# Altium schematic sheet Sheet1.SchDoc
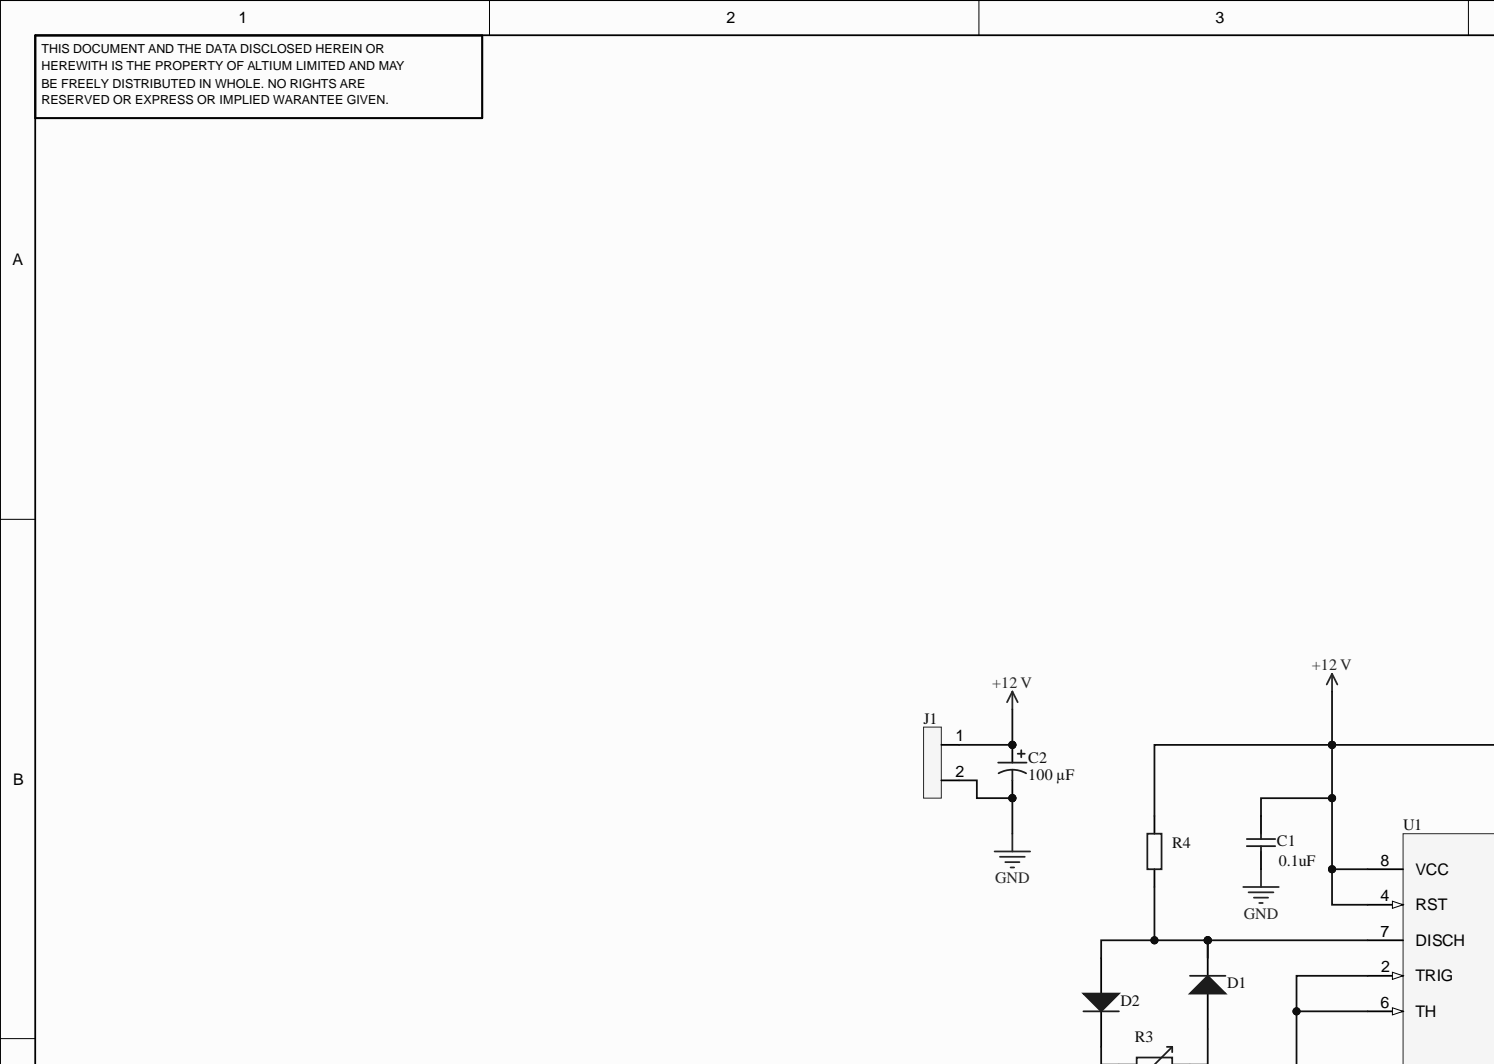
[diagram p0: Sheet1.SchDoc, top left]
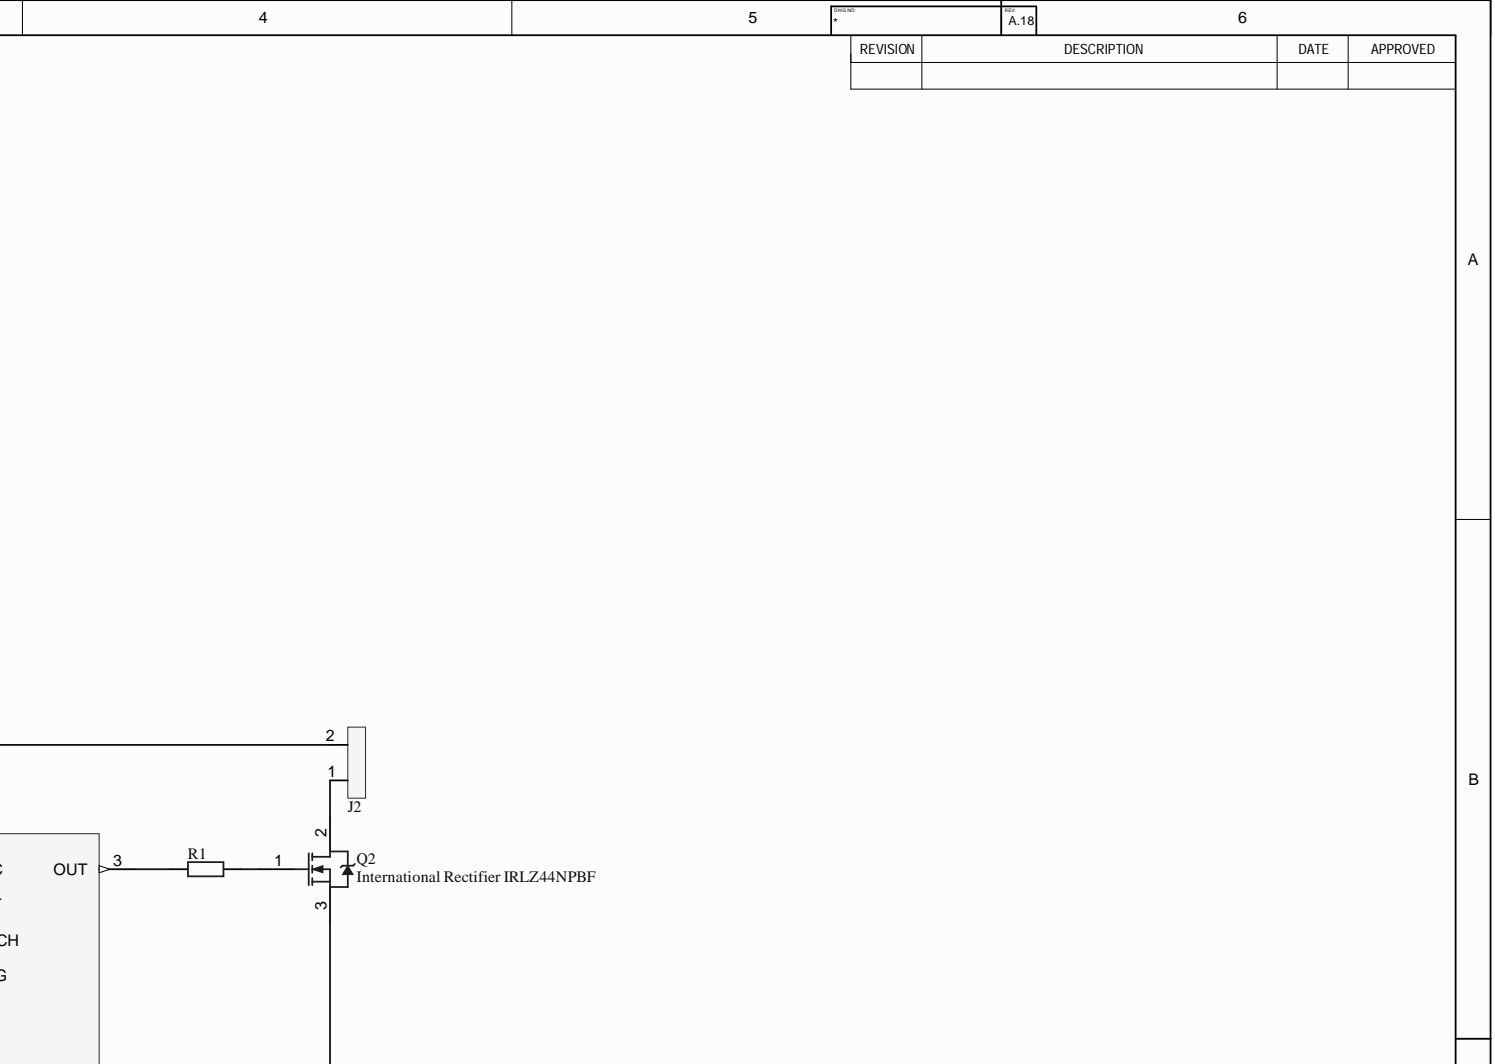
[diagram p1: Sheet1.SchDoc, top right]
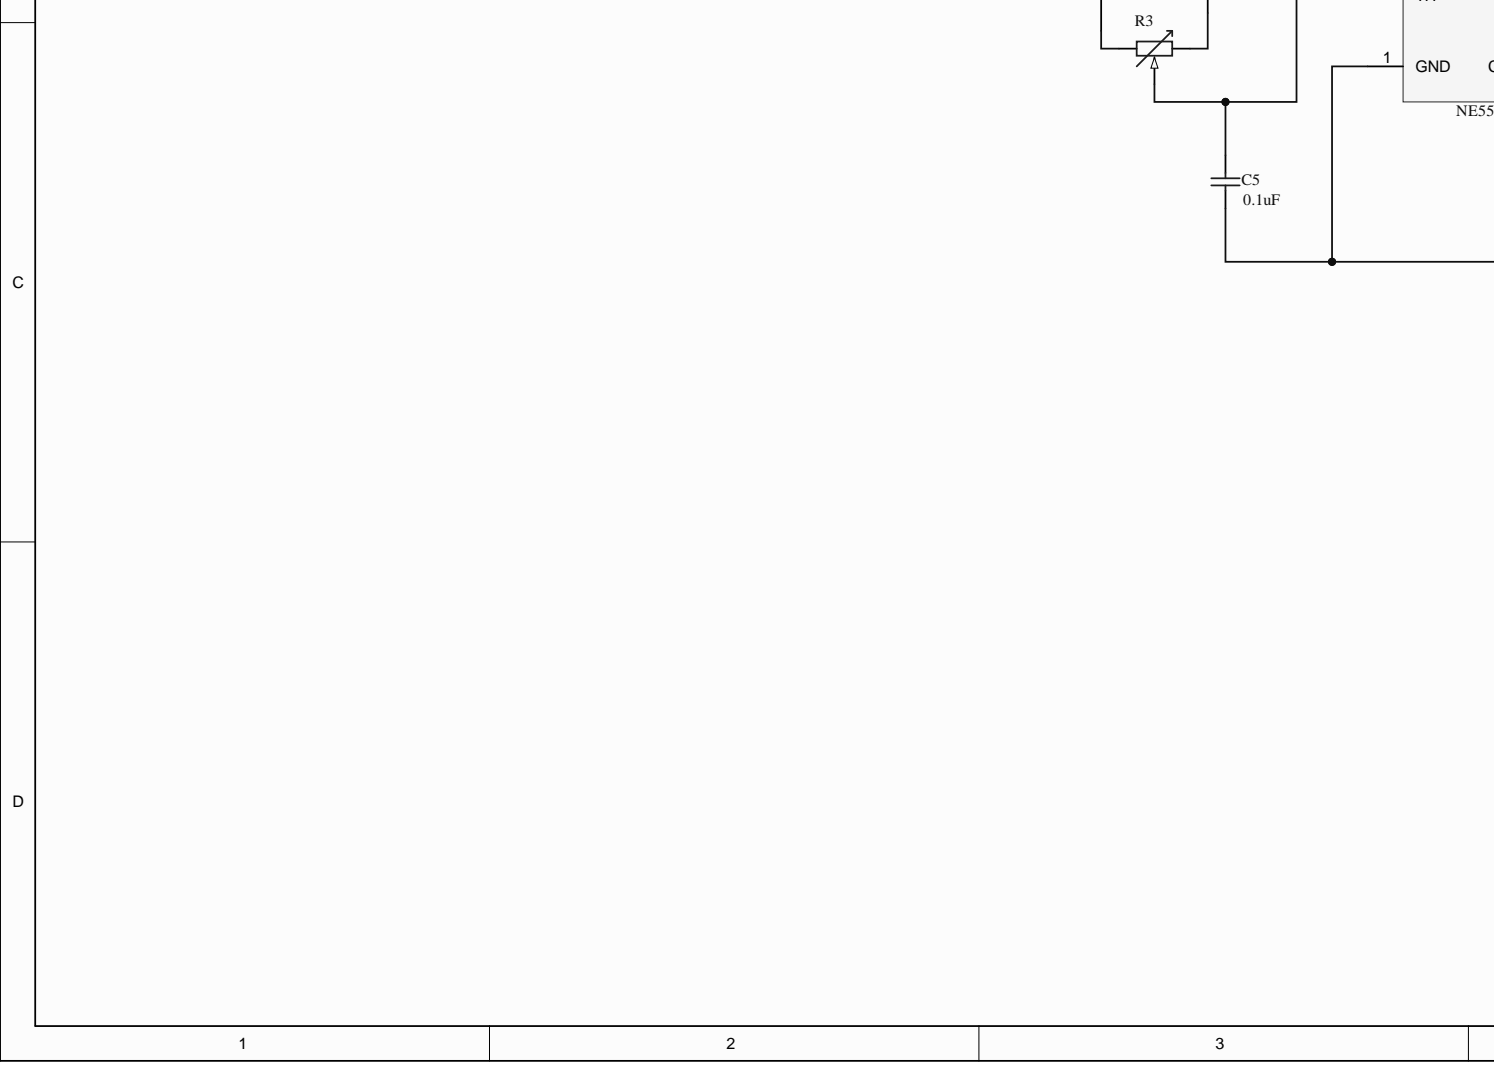
[diagram p2: Sheet1.SchDoc, bottom left]
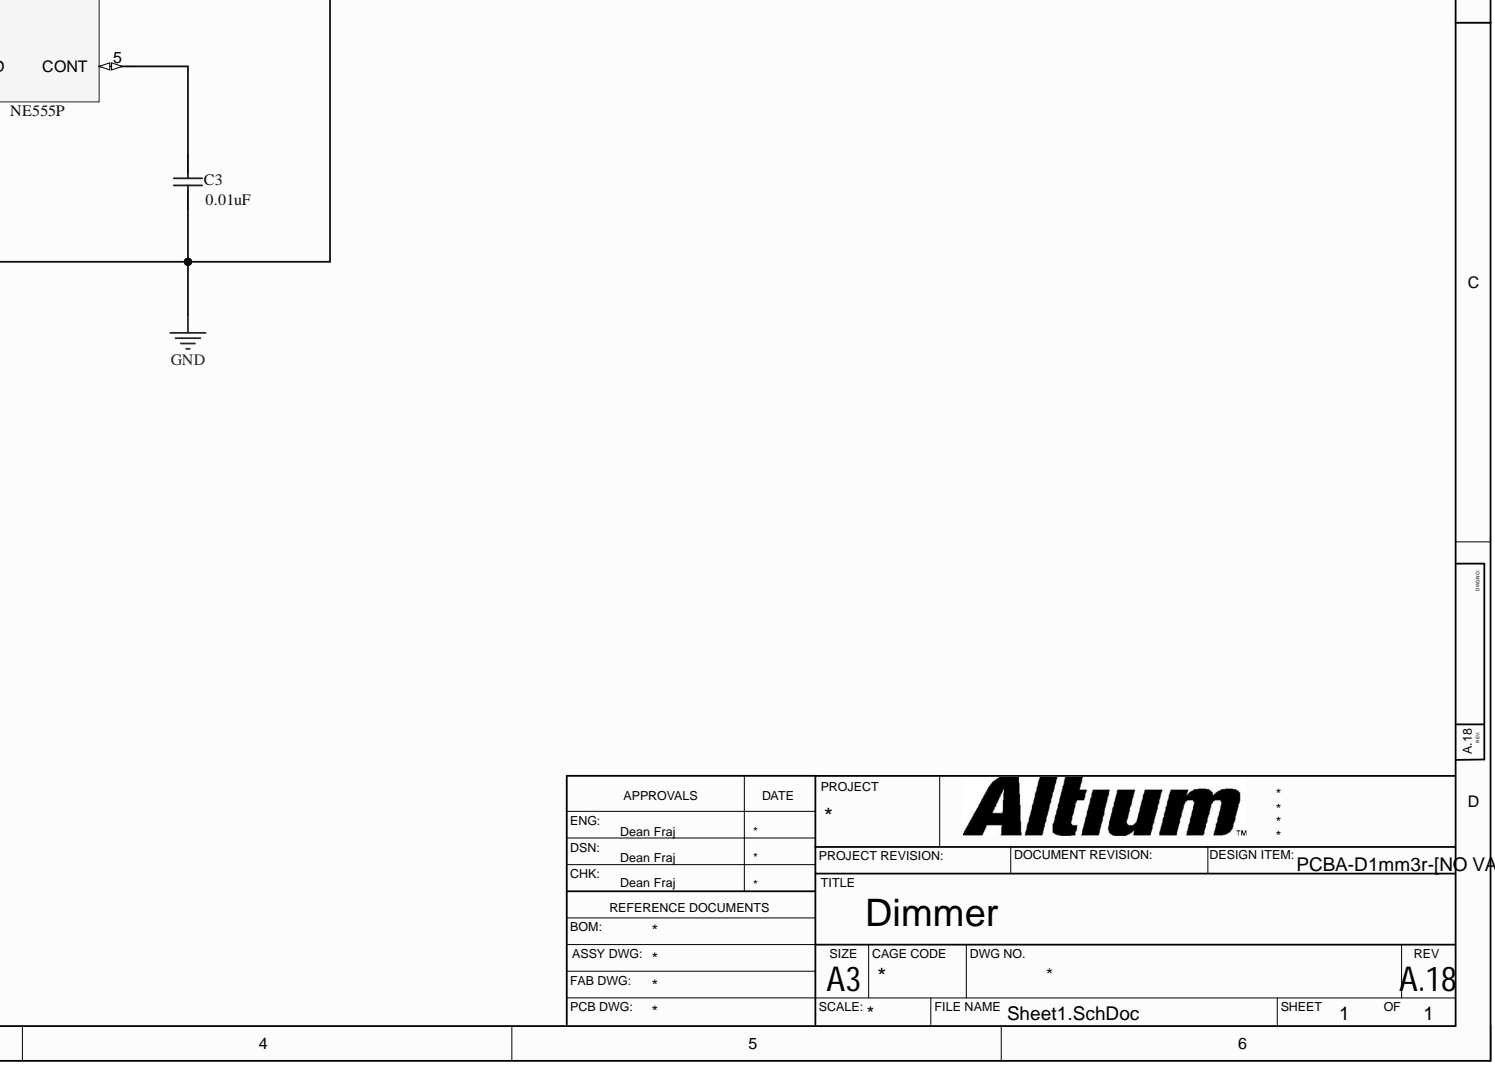
[diagram p3: Sheet1.SchDoc, bottom right]

## Parts
C1  value 0.1uF  [PCB-5q3b1ntq00bqadu474q8-1]  (hidden comment: Kemet C315C103M5R5TA)
C2  Capacitance: 100 µF  [PCB-i9faancde6oziqb23cfe-1]  (hidden comment: K50-35)
C3  value 0.01uF  [PCB-5q3b1ntq00bqadu474q8-1]  (hidden comment: Kemet C315C103M5R5TA)
C5  value 0.1uF  [PCB-5q3b1ntq00bqadu474q8-1]  (hidden comment: Kemet C315C103M5R5TA)
D1  1N4001RLG  [PCB-z2ypi7zr74mzpyjelpsh-1]  (value not drawn)
D2  1N4001RLG  [PCB-z2ypi7zr74mzpyjelpsh-1]  (value not drawn)
J1  Phoenix Contact 1985865  [PCB-2rqc9o5gc833wbn5o6r1-1]  (value not drawn)
J2  Phoenix Contact 1985865  [PCB-2rqc9o5gc833wbn5o6r1-1]  (value not drawn)
Q2  International Rectifier IRLZ44NPBF  [PCB-2k74bgo17gm00jmrfmhc-1]
R1  TE Connectivity LR1F10K  [PCB-wci5unuy01wd6rmy8zkd-6]  (value not drawn)
R3  Bourns PTV09A-2025F-B103  [PCB-3299071-1]  (value not drawn)
R4  Vishay PR02000201001JA100  [PCB-rdrmyk0f82b9h07n9a97-1]  (value not drawn)
U1  Manufacturer Part Number: NE555P  [PCB-0hu9wonejj2w43ody5uf-1]  (hidden comment: Texas Instruments NE555P)

## Nets
+12 V: C1.2 C2.1 J1.1 J2.2 R4.2 U1.4(RST) U1.8(VCC)
GND: C1.1 C2.2 C3.1 C5.1 J1.2 Q2.3 U1.1(GND)
NetC3_2: C3.2 U1.5(CONT)
NetC5_2: C5.2 R3.3 U1.2(TRIG) U1.6(TH)
NetD1_1: D1.1 R3.2
NetD1_2: D1.2 D2.1 R4.1 U1.7(DISCH)
NetD2_2: D2.2 R3.1
NetJ2_1: J2.1 Q2.2
NetQ2_1: Q2.1 R1.2
NetR1_1: R1.1 U1.3(OUT)

## Library data of the parts
C1 C3 C5 = CMP-f9eb30fd1b76262c-1: Capacitor, Ceramic, 10000pF, 50VDC, 20-% Tol, 20+% Tol, X7R-TC Code, -15, 15%-TC; Component Kind: Standard; Library Name: My Personal Space; Manufacturer Part Number: C315C103M5R5TA; Mounting Style: Through Hole; Octopart URL: http://octopart.com/c315c103m5r5ta-kemet-1886203; OctopartID: f9eb30fd1b76262c; RoHS: Compliant
C2 = CMP-92395a120dde4dfe-8: ee; Manufacturer Part Number: EEU-FR1E101B; Capacitance Tolerance: ±20%; Lead-Free Status: Lead Free; Voltage Rating (DC): 16 V; Component Kind: Standard; Lead Spacing: 2.5 mm; Library Name: My Personal Space; Lifecycle Status: Not Listed by Manufacturer; Octopart URL: http://octopart.com/eeu-fr1e101b-panasonic-18987649; OctopartID: 92395a120dde4dfe; Packaging: Tape & Reel (TR); REACH SVHC Compliance: No SVHC; RoHS: Compliant; Size-Diameter: 14 mm; Size-Height: 24 mm
D1 D2 = CMP-816519-3: Standard Recovery Rectifier, 2-Pin Axial_Lead, Pb-Free, Tape and Reel; Current Rating: 1.0 A; Lead-Free Status: Lead Free; Voltage Rating (DC): 50.0 V; Case/Package: DO-41; Component Kind: Standard; Halogen Free Status: Halogen Free; Library Name: My Personal Space; Lifecycle Status: Active; Manufacturer Part Number: 1N4001RLG; Mounting Style: Axial; Number of Pins: 2; Octopart Url: https://octopart.com/1n4001rlg-on+semiconductor-322109; OctopartID: 8cde45d043855319; Packaging: Cut Tape (CT); Polarity: Standard; REACH SVHC Compliance: No SVHC; RoHS: Compliant
J1 J2 = CMP-e7b42eb3d2883873-6: Conn PC Terminal Block 2 POS 5.08mm Solder ST Thru-Hole 13.5A; Lead-Free Status: Lead Free; Component Kind: Standard; Library Name: My Personal Space; Manufacturer Part Number: 1985865; Octopart URL: http://octopart.com/1985865-phoenix+contact-856154; OctopartID: e7b42eb3d2883873; RoHS: Compliant
Q2 = CMP-cd46043c92e51281-2: MOSFET, Power; N-Ch; VDSS 55V; RDS(ON) 0.022Ohm; ID 47A; TO-220AB; PD 110W; VGS +/-16V; Breakdown Voltage (Drain to Source): 55.0 V; Breakdown Voltage [Gate to Source]: -16.0 V to 16.0 V; Continuous Drain Current (Ids): 47.0 A; Drain to Source Resistance (on) (Rds): 22.0 mΩ; Lead-Free Status: Lead Free; Polarity: N-Channel; Power Dissipation: 83.0 W; Rise Time: 84.0 ns; Component Kind: Standard; Current Rating: 47.0 A; Drain to Source Voltage (Vds): 55.0 V; Library Name: My Personal Space; Lifecycle Status: Active; Manufacturer Part Number: IRLZ44NPBF; Mounting Style: Through Hole; Number of Pins: 3; Octopart URL: http://octopart.com/irlz44npbf-international+rectifier-7371461; OctopartID: cd46043c92e51281; Packaging: Bulk; Part Family: IRLZ44N; REACH SVHC Compliance: No SVHC; RoHS: Compliant; Voltage Rating (DC): 55.0 V
R1 = CMP-0a5cc3a37cecd59b-10: Res Metal Film 10K Ohm 1% 3/5W ±50ppm/°C Conformal AXL Thru-Hole Ammo Pack; ELV Compliant: Compliant; Power Rating: 600 mW; Voltage Rating (DC): 350 V; Component Kind: Standard; Library Name: My Personal Space; Lifecycle Status: Active; Manufacturer Part Number: LR1F10K; Mounting Style: Axial; Octopart URL: http://octopart.com/lr1f10k-te+connectivity-16077782; OctopartID: 0a5cc3a37cecd59b; Packaging: Ammo Pack; REACH SVHC Compliance: No SVHC; Resistance: 10k; Resistance Tolerance: ±1%; RoHS: Compliant
R3 = CMP-3299071-4: Potentiometers 10K 20% 9mm Linear Snap In; Power Rating: 0.05 W; Resistance: 10000.0 Ω; Voltage Rating (DC): 50.0 V; Component Kind: Standard; Library Name: My Personal Space; Manufacturer Part Number: PTV09A-2025F-B103; Mounting Style: Radial; Octopart Url: https://octopart.com/ptv09a-2025f-b103-bourns-1025990; OctopartID: a94660432be67681; Resistance Tolerance: ±20%; RoHS: Compliant
R4 = CMP-6f11a493ffc0b0d6-7: PR02 Series Axial Metal Film Resistor 1kOhms +/-5% 2W +/-250ppm/degC; Lead-Free Status: Lead Free; Operating Voltage: 500 V; Power Rating: 2.00 W; Resistance: 1.00 kΩ; Resistance Tolerance: ±5%; Component Kind: Standard; Library Name: My Personal Space; Manufacturer Part Number: PR02000201001JA100; Mounting Style: Axial; Octopart URL: https://octopart.com/pr02000201001ja100-vishay-42513847; OctopartID: 6f11a493ffc0b0d6; Packaging: Ammo Pack; RoHS: Compliant; Size-Length: 12.0 mm; Size-Width: 3.90 mm; Voltage Rating (DC): 500 V
U1 = CMP-8f734534f03350b4-22: Timer, Precision; PDIP; 8; 9 ma (typ.); 16 V (max.); 12.5 V (typ.); Current Rating: 10.0 A; Frequency: 500 kHz; Lead-Free Status: Lead Free; Quiescent Current: 2.00 mA; Supply Current: 15.0 mA (max); Supply Voltage (DC): 16.0 V (max), 4.50 V (min); Case/Package: PDIP; Component Kind: Standard; Library Name: My Personal Space; Lifecycle Status: Active; Manufacturer: Texas Instruments; Mounting Style: Through Hole; Octopart URL: https://octopart.com/ne555p-texas+instruments-55400998; OctopartID: 8f734534f03350b4; Operating Temperature: 70.0 °C (max); Packaging: Tube; REACH SVHC Compliance: No SVHC; RoHS: Compliant
